# Altium schematic sheet Hermes_2.0.SchDoc
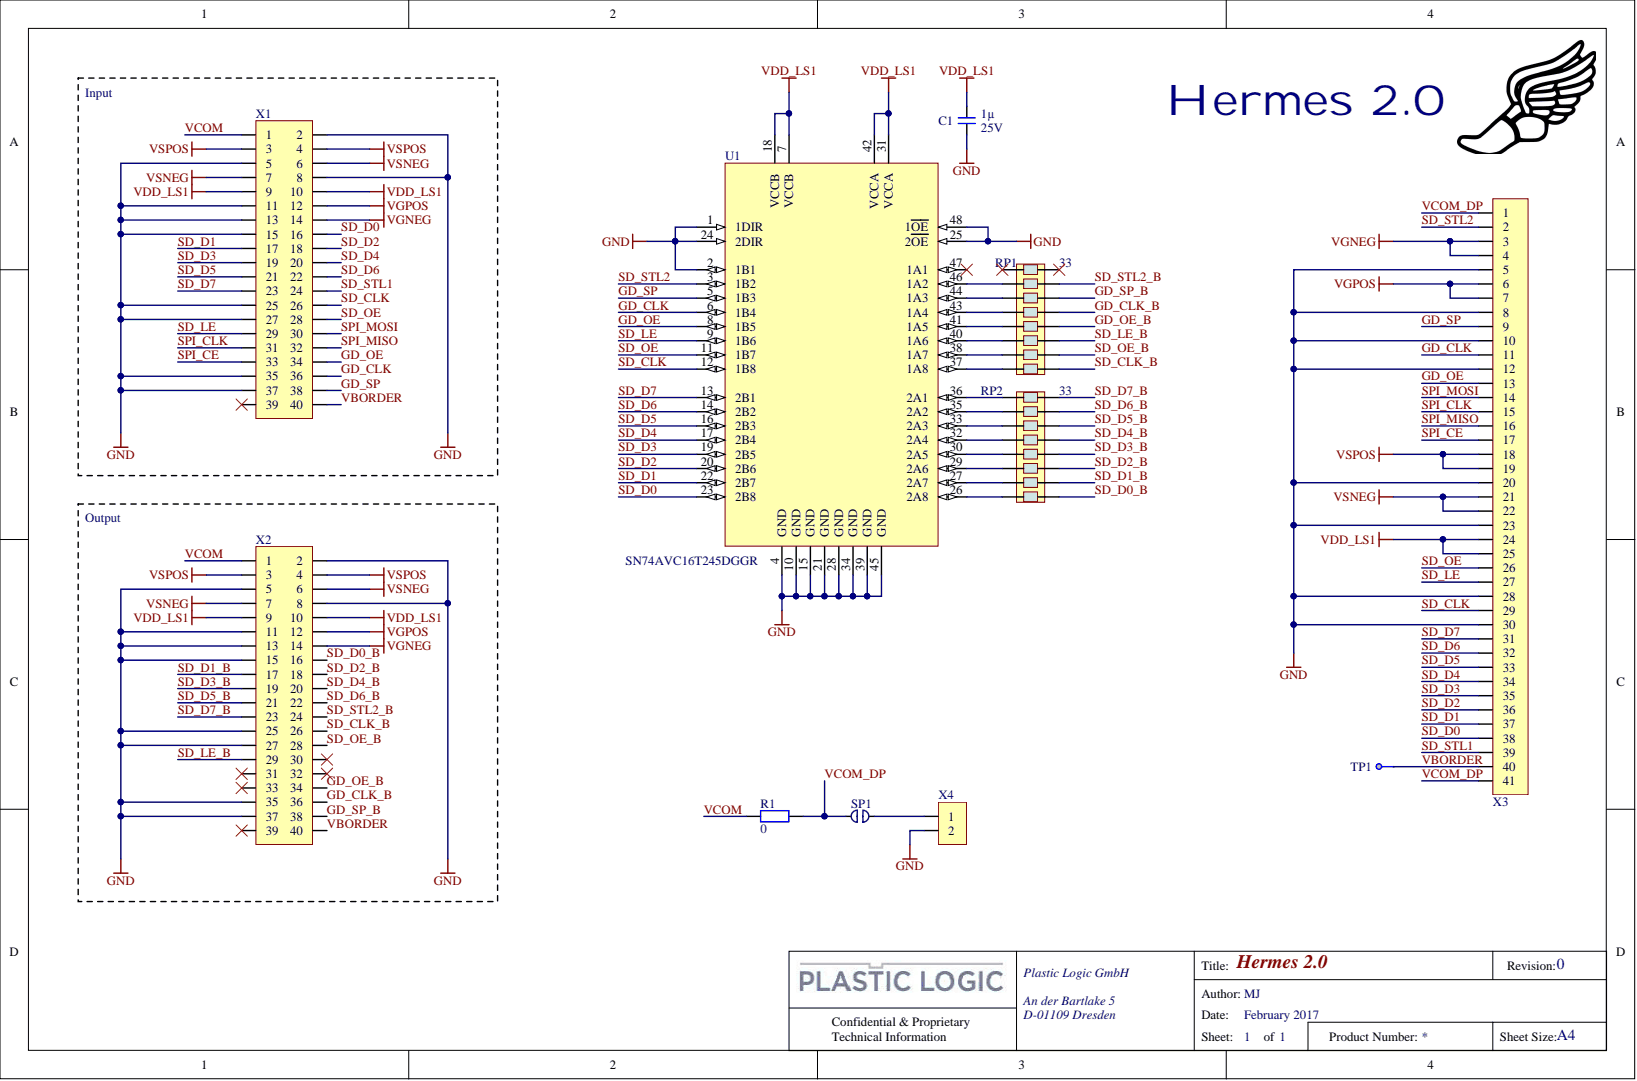
[diagram p0: Hermes_2.0.SchDoc]

## Parts
C1  1µ  Voltage: 25V  [C0603-0.9mm]
R1  0  [R0603]
RP1  8 ResPack 33 Ohm  [CAC-80P639X159-16N]  (value not drawn)
RP2  8 ResPack 33 Ohm  [CAC-80P639X159-16N]  (value not drawn)
SP1  solder points  [solder points]  (value not drawn)  (no BOM)
TP1  Test Point  [Test Pad 1.5mm]  (value not drawn)  (no BOM)
U1  SN74AVC16T245DGGR  [TSSOP50P810X120-48N]
X1  SHF-120-01-L-D-SM-K-TR  [SHF-120-01-SM]  (value not drawn)
X2  SHF-120-01-L-D-SM-K-TR  [SHF-120-01-SM]  (value not drawn)
X3  FH35C-41S-0.3SHW(99)  [FH35C-41S]  (value not drawn)
X4  Header_1x2  [Generic Header 1x2]  (value not drawn)

## Nets
GND: C1.2 U1.1(1DIR) U1.2(1B1) U1.4(GND) U1.10(GND) U1.15(GND) U1.21(GND) U1.24(2DIR) U1.25(2OE) U1.28(GND) U1.34(GND) U1.39(GND) U1.45(GND) U1.48(1OE) X1.2 X1.5 X1.8 X1.11 X1.13 X1.15 X1.25 X1.27 X1.35 X1.37 X2.2 X2.5 X2.8 X2.11 X2.13 X2.15 X2.25 X2.27 X2.35 X2.37 X3.5 X3.8 X3.10 X3.12 X3.20 X3.23 X3.28 X3.30 X4.2
VDD_LS1: C1.1 U1.7(VCCB) U1.18(VCCB) U1.31(VCCA) U1.42(VCCA) X1.9 X1.10 X2.9 X2.10 X3.24 X3.25
VGNEG: X1.14 X2.14 X3.3 X3.4
VGPOS: X1.12 X2.12 X3.6 X3.7
VSNEG: X1.6 X1.7 X2.6 X2.7 X3.21 X3.22
VSPOS: X1.3 X1.4 X2.3 X2.4 X3.18 X3.19
GD_CLK: U1.6(1B4) X1.36 X3.11
GD_CLK_B: RP1.13 X2.36
GD_OE: U1.8(1B5) X1.34 X3.13
GD_OE_B: RP1.12 X2.34
GD_SP: U1.5(1B3) X1.38 X3.9
GD_SP_B: RP1.14 X2.38
SD_CLK: U1.12(1B8) X1.26 X3.29
SD_CLK_B: RP1.9 X2.26
SD_D0: U1.23(2B8) X1.16 X3.38
SD_D0_B: RP2.9 X2.16
SD_D1: U1.22(2B7) X1.17 X3.37
SD_D1_B: RP2.10 X2.17
SD_D2: U1.20(2B6) X1.18 X3.36
SD_D2_B: RP2.11 X2.18
SD_D3: U1.19(2B5) X1.19 X3.35
SD_D3_B: RP2.12 X2.19
SD_D4: U1.17(2B4) X1.20 X3.34
SD_D4_B: RP2.13 X2.20
SD_D5: U1.16(2B3) X1.21 X3.33
SD_D5_B: RP2.14 X2.21
SD_D6: U1.14(2B2) X1.22 X3.32
SD_D6_B: RP2.15 X2.22
SD_D7: U1.13(2B1) X1.23 X3.31
SD_D7_B: RP2.16 X2.23
SD_LE: U1.9(1B6) X1.29 X3.27
SD_LE_B: RP1.11 X2.29
SD_OE: U1.11(1B7) X1.28 X3.26
SD_OE_B: RP1.10 X2.28
SD_STL1: X1.24 X3.39
SD_STL2: U1.3(1B2) X3.2
SD_STL2_B: RP1.15 X2.24
SPI_CE: X1.33 X3.17
SPI_CLK: X1.31 X3.15
SPI_MISO: X1.32 X3.16
SPI_MOSI: X1.30 X3.14
VBORDER: TP1.1 X1.40 X2.40 X3.40
VCOM: R1.2 X1.1 X2.1
VCOM_DP: R1.1 SP1.1 X3.1 X3.41
NetRP1_2: RP1.2 U1.46(1A2)
NetRP1_3: RP1.3 U1.44(1A3)
NetRP1_4: RP1.4 U1.43(1A4)
NetRP1_5: RP1.5 U1.41(1A5)
NetRP1_6: RP1.6 U1.40(1A6)
NetRP1_7: RP1.7 U1.38(1A7)
NetRP1_8: RP1.8 U1.37(1A8)
NetRP2_1: RP2.1 U1.36(2A1)
NetRP2_2: RP2.2 U1.35(2A2)
NetRP2_3: RP2.3 U1.33(2A3)
NetRP2_4: RP2.4 U1.32(2A4)
NetRP2_5: RP2.5 U1.30(2A5)
NetRP2_6: RP2.6 U1.29(2A6)
NetRP2_7: RP2.7 U1.27(2A7)
NetRP2_8: RP2.8 U1.26(2A8)
NetSP1_2: SP1.2 X4.1

Not connected: RP1 1 16; U1 47(1A1); X1 39; X2 30 31 32 33 39

## Library data of the parts
C1 = C 0603 1µ 25V: Manufacturer: Generic; Material: X5R; Tolerance: 10%; Component Kind: Standard; Description: C 0603 1µ 25V; Package / Case: 0603; Value: 1µ; Library Name: Hermes_2.0.SchLib
R1 = R0603-0: RES SMD 0 OHM 1/10W 0603; Manufacturer: Generic; Component Kind: Standard; Package / Case: 0603; Power: 1/10W; Supplier Device Package: 0603; Value: 0; Library Name: Hermes_2.0.SchLib
RP1 RP2 = 8RES Pack: RES ARRAY 8 RES 33 OHM 2506; Manufacturer: Bourns Inc.; Manufacturer Part Number: CAT16-33R0F8LF; Circuit Type: Isolated; Number of Resistors: 8; Power Per Element: 62.5mW; Resistance (Ohms): 33; Temperature Coefficient: ±200ppm/°C; Tolerance: ±1%; Applications: -; Category: Resistors; Component Kind: Standard; ComponentLink1Description: http://www.bourns.com/docs/Product-Datasheets/CATCAY.pdf; ComponentLink1URL: http://www.bourns.com/docs/Product-Datasheets/CATCAY.pdf; Height: 0.030" (0.75mm); Mounting Type: Surface Mount; Number of Pins: 16; Operating Temperature: -55°C ~ 125°C; Package / Case: 2506, Concave, Long Side Terminals; Packaging: Tape & Reel (TR); Pricing 1: 4000=0.16828 (EUR); RoHS: RoHS Compliant; Size / Dimension: 0.252" L x 0.063" W (6.40mm x 1.60mm); Stock 1: 0; Supplier 1: Digi-Key; Supplier Device Package: 2406; Supplier Part Number 1: CAT16-33R0F8LF-ND; Library Name: Hermes_2.0.SchLib
SP1 = solder points: solder points for manual shorting; Library Name: Hermes_2.0.SchLib
TP1 = Test Point 1mm: Description: Test Point 1mm; Library Name: Hermes_2.0.SchLib
U1 = SN74AVC16T245DGGR: IC BUS TRANSCVR 16BIT 48TSSOP; Manufacturer: Texas Instruments; Channels per Circuit: 8; Channel Type: Bidirectional; Data Rate: 380Mbps; Number of Circuits: 2; Output Type: Tri-State, Non-Inverted; Translator Type: Voltage Level; Voltage - VCCA: 1.2 V ~ 3.6 V; Category: Integrated Circuits (ICs); Component Kind: Standard; ComponentLink1Description: http://www.ti.com/lit/ds/symlink/sn74avc16t245.pdf; ComponentLink1URL: http://www.ti.com/lit/ds/symlink/sn74avc16t245.pdf; Features: -; Input Signal: -; Manufacturer Part Number: SN74AVC16T245DGGR; Mounting Type: Surface Mount; Operating Temperature: -40°C ~ 85°C (TA); Output Signal: -; Package / Case: 48-TFSOP (0.240", 6.10mm Width); Packaging: Digi-Reel®; Pricing 1: 1=2.08, 10=1.865, 100=1.496, 500=1.22962, 1000=1.01784 (EUR); RoHS: RoHS Compliant; Stock 1: 6463; Supplier 1: Digi-Key; Supplier Device Package: 48-TSSOP; Supplier Part Number 1: 296-18197-6-ND; Voltage - VCCB: 1.2 V ~ 3.6 V; Library Name: Hermes_2.0.SchLib
X1 X2 = SHF-120-01-L-D-SM-K-TR: SAMTEC - SHF-120-01-L-D-SM-K-TR - HEADER, 1.27MM, SMT, 2X20WAY; Component Kind: Standard; ComponentLink1Description: http://www.farnell.com/cad/1788271.pdf; ComponentLink1URL: http://www.farnell.com/cad/1788271.pdf; ComponentLink2Description: http://www.farnell.com/datasheets/1927736.pdf; ComponentLink2URL: http://www.farnell.com/datasheets/1927736.pdf; Manufacturer: SAMTEC; Manufacturer Part Number: SHF-120-01-L-D-SM-K-TR; Pricing 1: 125=2.92, 250=2.63, 375=2.43, 500=2.32, 625=2.26, 750=2.22, 1000=2.16 (GBP); RoHS: YES; Stock 1: 200; Supplier 1: Farnell; Supplier Part Number 1: 2416490; Library Name: Hermes_1.0.SchLib
X3 = FH35C-41S-0.3SHW(99): CONN FPC 41POS 0.30MM R/A; Manufacturer: Hirose Electric Co Ltd; Actuator Material: Polyamide (PA), Nylon; Cable End Type: Tapered; Connector/Contact Type: Contacts, Top and Bottom; Current Rating: 0.2A; FFC, FCB Thickness: 0.20mm; Locking Feature: Flip Lock, Backlock; Material Flammability Rating: UL94 V-0; Number of Positions: 41; Pitch: 0.012" (0.30mm); Voltage Rating: 30V; Category: Connectors, Interconnects; Component Kind: Standard; ComponentLink1Description: https://www.hirose.com/product/download/?distributor=digikey&type=2d&lang=en&num=FH35C-41S-0.3SHW(99); ComponentLink1URL: https://www.hirose.com/product/download/?distributor=digikey&type=2d&lang=en&num=FH35C-41S-0.3SHW(99); Contact Finish: Gold; Contact Material: Phosphor Bronze; Features: -; Flat Flex Type: FPC; Height Above Board: 0.036" (0.90mm); Housing Material: Liquid Crystal Polymer (LCP); Manufacturer Part Number: FH35C-41S-0.3SHW(99); Mounting Type: Surface Mount, Right Angle; Operating Temperature: -55°C ~ 85°C; Packaging: Cut Tape (CT); Part Status: Active; Pricing 1: 1=3.08, 10=2.963, 25=2.716, 50=2.5926, 100=2.469, 250=2.1604 (EUR); RoHS: RoHS Compliant; Stock 1: 786; Supplier 1: Digi-Key; Supplier Part Number 1: H122278CT-ND; Termination: Solder; Library Name: Hermes_2.0.SchLib
X4 = Header_1x2: .025 SQ Post Terminal Strip Assembly, 1x2 Pins, Vertical, 2.54mm Pitch, Male; Component Kind: Standard; Library Name: Hermes_2.0.SchLib
